# Altium schematic sheet SCH_SHT30.SchDoc(SCH_SHT30)
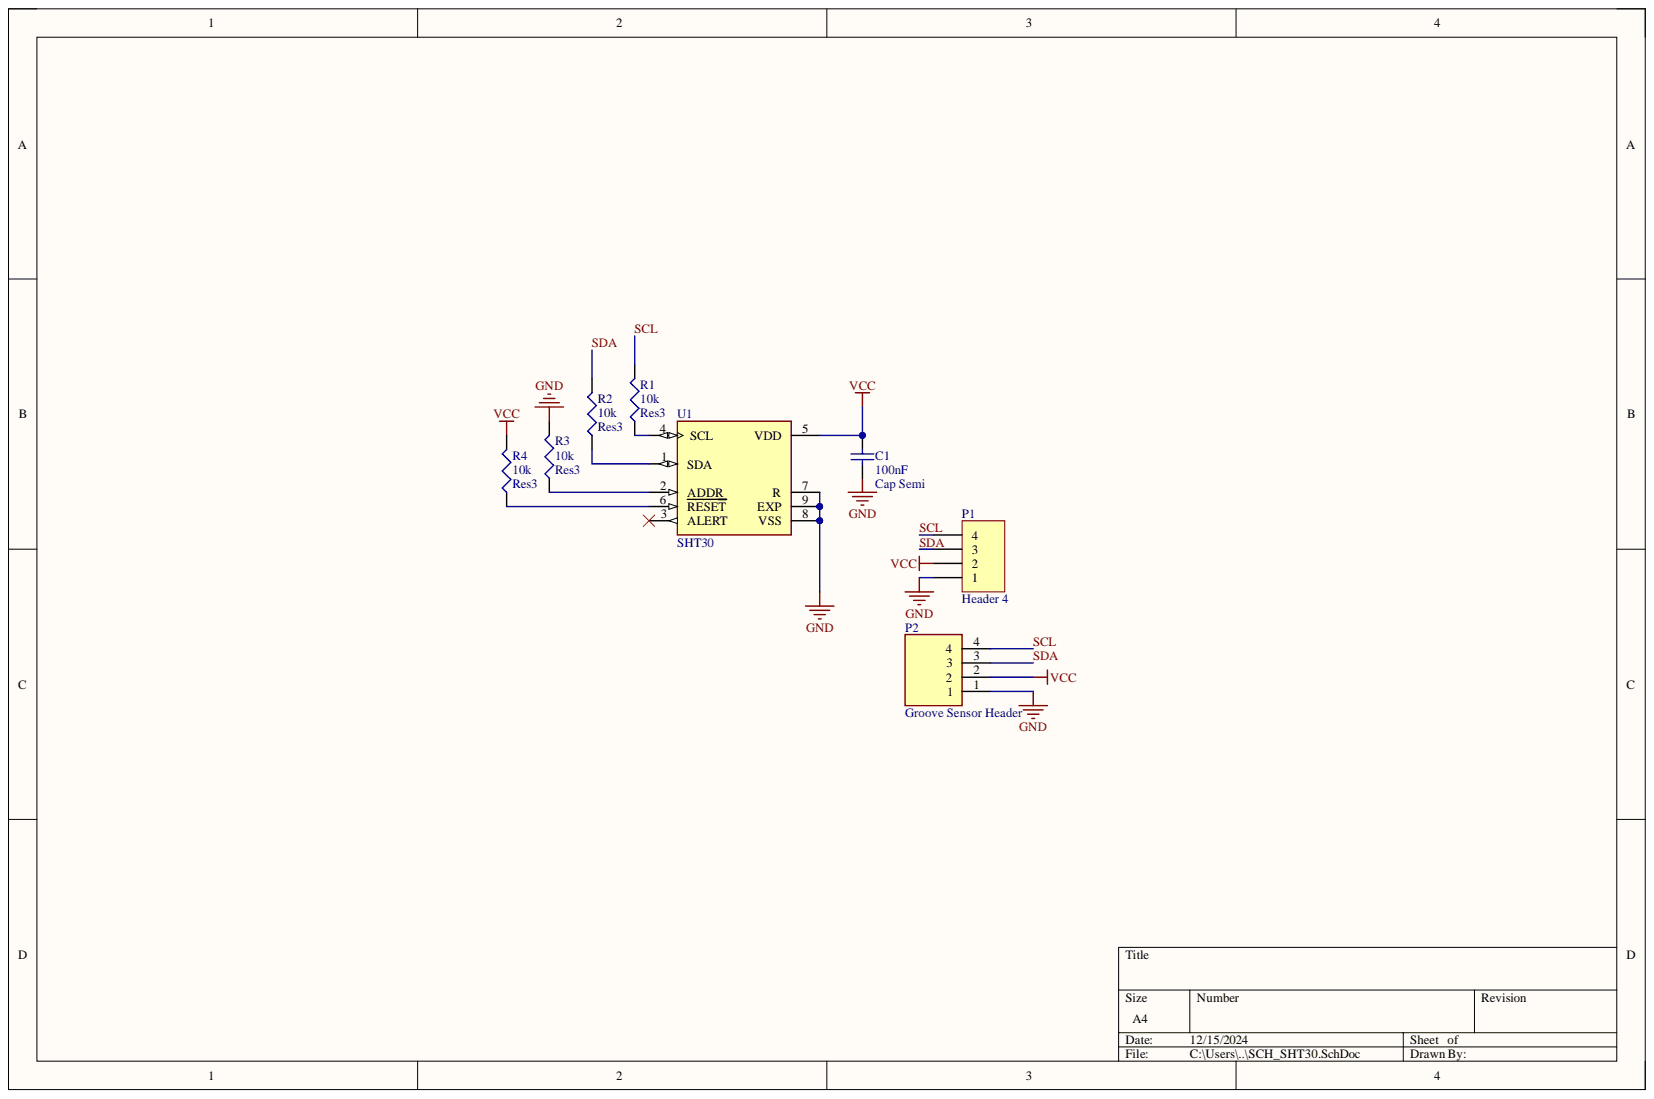
[diagram p0: SCH_SHT30.SchDoc]

## Parts
C1  Cap Semi  value 100nF  [1608[0603]]
P1  Header 4  [HDR1X4]
P2  Groove Sensor Header  [SEEED_110990030]
R1  Res3  value 10k  [J1-0603]
R2  Res3  value 10k  [J1-0603]
R3  Res3  value 10k  [J1-0603]
R4  Res3  value 10k  [J1-0603]
U1  SHT30  [XDCR_SHT30-DIS-B10KS]

## Nets
GND: C1.1 P1.1 P2.1 R3.2 U1.7(R) U1.8(VSS) U1.9(EXP)
VCC: C1.2 P1.2 P2.2 R4.2 U1.5(VDD)
SCL: P1.4 P2.4 R1.2
SDA: P1.3 P2.3 R2.2
NetR1_1: R1.1 U1.4(SCL)
NetR2_1: R2.1 U1.1(SDA)
NetR3_1: R3.1 U1.2(ADDR)
NetR4_1: R4.1 U1.6(RESET)

Not connected: U1 3(ALERT)

## Library data of the parts
C1 = Cap Semi: Capacitor (Semiconductor SIM Model); Component Kind: Standard; LatestRevisionDate: 29-May-2009; LatestRevisionNote: IPC-7351 Footprint Added.; Library Name: Miscellaneous Devices.IntLib; PackageDescription: Chip Capacitor; PackageReference: C1206; Published: 8-Jun-2000; Signal Integrity: Cap Semi; Simulation: CAP; Publisher: Altium Limited
P1 = Header 4: Header, 4-Pin; Component Kind: Standard; LatestRevisionDate: 17-Jul-2002; LatestRevisionNote: Re-released for DXP Platform.; Library Name: Miscellaneous Connectors.IntLib; Signal Integrity: Connector; Publisher: Altium Limited
P2 = CMP-002-00072-1: GROVE 2MM 4PIN VERT CONN 10PCS; MF: Seeed Technology; MP: 110990030; Arrow_Electronics-Purchase-URL: https://snapeda.com/shop?store=Arrow+Electronics&id=583576; Availability: Good; Case/Package: None; Component Kind: Standard; Component Type: Standard; Design Item ID: 110990030; Mouser-Purchase-URL: https://snapeda.com/shop?store=Mouser&id=583576; Pins: 4; Price: None; SnapEDA_Link: https://www.snapeda.com/parts/110990030/Seeed%20Technology%20Co.,%20Ltd/view-part/583576/?ref=snap; Source: 110990030.SchLib; Library Name: Titouan Lasquellec
R1-R4 = Res3: Resistor; Component Kind: Standard; LatestRevisionDate: 29-May-2009; LatestRevisionNote: IPC-7351 Footprint Added.; Library Name: Miscellaneous Devices.IntLib; PackageDescription: Chip Resistor; PackageReference: J1-0603; Published: 8-Jun-2000; Signal Integrity: Res; Simulation: RESISTOR; Publisher: Altium Limited
U1 = SHT30-DIS-B10KS: SENSOR HUMID/TEMP 5V I2C 2% SMD; Availability: Unavailable; Component Kind: Standard; Library Name: SHT30-DIS-B10KS.IntLib; MP: SHT30-DIS-B10KS; Package: None; Price: None
